# Altium schematic sheet Configuration Switches and DAC Rev 5.SchDoc("Configuration Switches and DAC Rev 5")
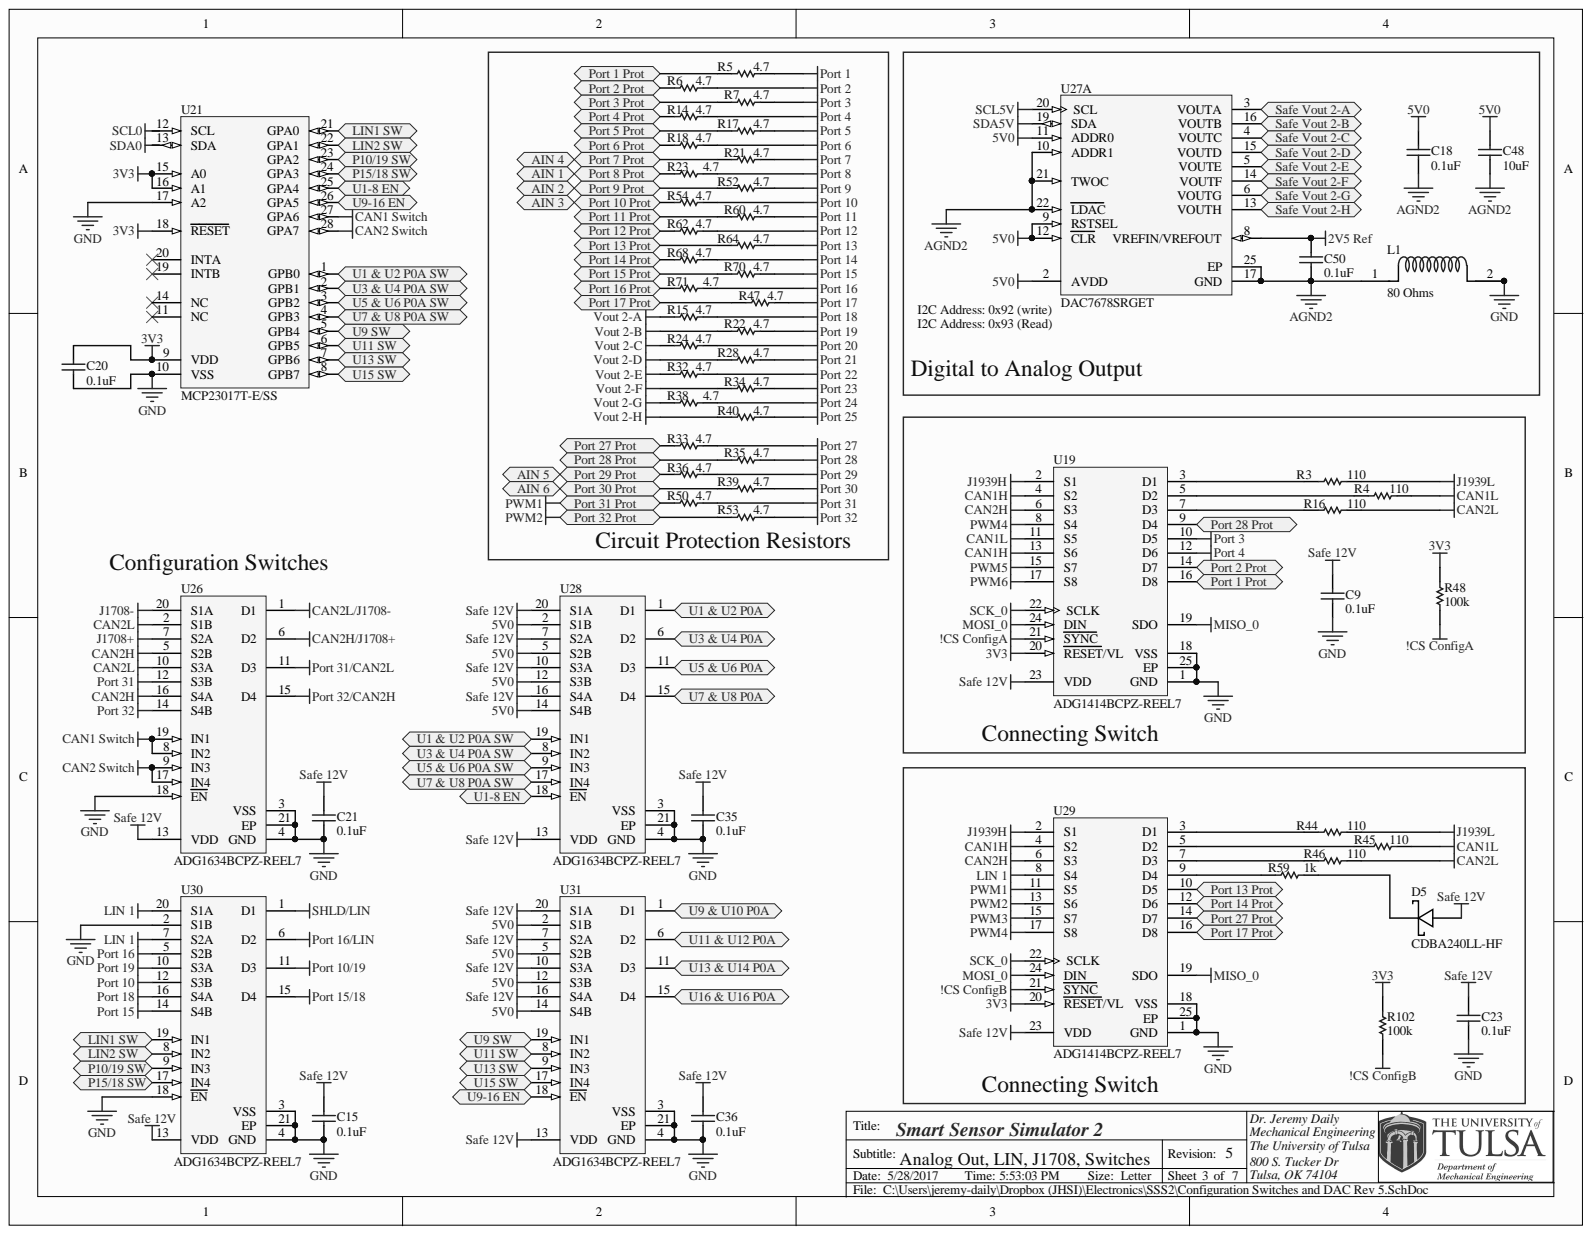
[diagram p0: Configuration Switches and DAC Rev 5.SchDoc]

## Parts
C9  0.1uF  [0603]
C15  0.1uF  [0603]
C18  0.1uF  [0603]
C20  0.1uF  [0603]
C21  0.1uF  [0603]
C23  0.1uF  [0603]
C35  0.1uF  [0603]
C36  0.1uF  [0603]
C48  10uF  [0603]
C50  0.1uF  [0603]
D5  CDBA240LL-HF  [SMA with A and K]
L1  80 Ohms  [0805]
R3  110  [0603]
R4  110  [0603]
R5  4.7  [0603]
R6  4.7  [0603]
R7  4.7  [0603]
R14  4.7  [0603]
R15  4.7  [0603]
R16  110  [0603]
R17  4.7  [0603]
R18  4.7  [0603]
R21  4.7  [0603]
R22  4.7  [0603]
R23  4.7  [0603]
R24  4.7  [0603]
R28  4.7  [0603]
R32  4.7  [0603]
R33  4.7  [0603]
R34  4.7  [0603]
R35  4.7  [0603]
R36  4.7  [0603]
R38  4.7  [0603]
R39  4.7  [0603]
R40  4.7  [0603]
R44  110  [0603]
R45  110  [0603]
R46  110  [0603]
R47  4.7  [0603]
R48  100k  [0603]
R50  4.7  [0603]
R52  4.7  [0603]
R53  4.7  [0603]
R54  4.7  [0603]
R59  1k
R60  4.7  [0603]
R62  4.7  [0603]
R64  4.7  [0603]
R68  4.7  [0603]
R70  4.7  [0603]
R71  4.7  [0603]
R102  100k  [0603]
U19  ADG1414BCPZ-REEL7  [ADI-CP-24-3_M]
U21  MCP23017T-E/SS  [SSOP-SS28_N]
U26  ADG1634BCPZ-REEL7  [ADI-CP-20-4_N]
U27  DAC7678SRGET  [TI-RGE24-2700X2700TP_V]  (part A)
U28  ADG1634BCPZ-REEL7  [ADI-CP-20-4_N]
U29  ADG1414BCPZ-REEL7  [ADI-CP-24-3_M]
U30  ADG1634BCPZ-REEL7  [ADI-CP-20-4_N]
U31  ADG1634BCPZ-REEL7  [ADI-CP-20-4_N]

## Ports
AIN 1, AIN 2, AIN 3, AIN 4, AIN 5, AIN 6, LIN1 SW, LIN2 SW, P10/19 SW, P15/18 SW, PORT 1 PROT, PORT 2 PROT, PORT 3 PROT, PORT 4 PROT, PORT 5 PROT, PORT 6 PROT, PORT 7 PROT, PORT 8 PROT, PORT 9 PROT, PORT 10 PROT, PORT 11 PROT, PORT 12 PROT, PORT 13 PROT, PORT 14 PROT, PORT 15 PROT, PORT 16 PROT, PORT 17 PROT, PORT 27 PROT, PORT 28 PROT, PORT 29 PROT, PORT 30 PROT, PORT 31 PROT, PORT 32 PROT, SAFE VOUT 2-A, SAFE VOUT 2-B, SAFE VOUT 2-C, SAFE VOUT 2-D, SAFE VOUT 2-E, SAFE VOUT 2-F, SAFE VOUT 2-G, SAFE VOUT 2-H, U1 & U2 P0A, U1 & U2 P0A SW, U1-8 EN, U3 & U4 P0A, U3 & U4 P0A SW, U5 & U6 P0A, U5 & U6 P0A SW, U7 & U8 P0A, U7 & U8 P0A SW, U9 & U10 P0A, U9 SW, U9-16 EN, U11 & U12 P0A, U11 SW, U13 & U14 P0A, U13 SW, U15 SW, U16 & U16 P0A

## Nets
2V5 REF: C50.2 U27.8(VREFIN/VREFOUT)
3V3: C20.2 R48.2 R102.2 U19.20(RESET/VL) U21.9(VDD) U21.15(A0) U21.16(A1) U21.18(RESET) U29.20(RESET/VL)
5V0: C18.2 C48.2 U27.2(AVDD) U27.9(RSTSEL) U27.11(ADDR0) U27.12(CLR) U28.2(S1B) U28.5(S2B) U28.12(S3B) U28.14(S4B) U31.2(S1B) U31.5(S2B) U31.12(S3B) U31.14(S4B)
!CS CONFIGA: R48.1 U19.21(SYNC)
!CS CONFIGB: R102.1 U29.21(SYNC)
AGND2: C18.1 C48.1 C50.1 L1.1 U27.10(ADDR1) U27.17(GND) U27.21(TWOC) U27.22(LDAC) U27.25(EP)
CAN1 SWITCH: U21.27(GPA6) U26.8(IN2) U26.19(IN1)
CAN1H: U19.4(S2) U19.13(S6) U29.4(S2)
CAN1L: R4.1 R45.1 U19.11(S5)
CAN2 SWITCH: U21.28(GPA7) U26.9(IN3) U26.17(IN4)
CAN2H: U19.6(S3) U26.5(S2B) U26.16(S4A) U29.6(S3)
CAN2H/J1708+: U26.6(D2)
CAN2L: R16.2 R46.2 U26.2(S1B) U26.10(S3A)
CAN2L/J1708-: U26.1(D1)
GND: C9.1 C15.1 C20.1 C21.1 C23.1 C35.1 C36.1 L1.2 U19.1(GND) U19.18(VSS) U19.25(EP) U21.10(VSS) U21.17(A2) U26.3(VSS) U26.4(GND) U26.18(EN) U26.21(EP) U28.3(VSS) U28.4(GND) U28.21(EP) U29.1(GND) U29.18(VSS) U29.25(EP) U30.2(S1B) U30.3(VSS) U30.4(GND) U30.18(EN) U30.21(EP) U31.3(VSS) U31.4(GND) U31.21(EP)
J1708+: U26.7(S2A)
J1708-: U26.20(S1A)
J1939H: U19.2(S1) U29.2(S1)
J1939L: R3.1 R44.1
LIN 1: U29.8(S4) U30.7(S2A) U30.20(S1A)
MISO_0: U19.19(SDO) U29.19(SDO)
MOSI_0: U19.24(DIN) U29.24(DIN)
PORT 1: R5.1
PORT 2: R6.1
PORT 3: R7.1 U19.10(D5)
PORT 4: R14.1 U19.12(D6)
PORT 5: R17.1
PORT 6: R18.1
PORT 7: R21.1
PORT 8: R23.2
PORT 9: R52.1
PORT 10: R54.1 U30.12(S3B)
PORT 10/19: U30.11(D3)
PORT 11: R60.1
PORT 12: R62.1
PORT 13: R64.1
PORT 14: R68.1
PORT 15: R70.1 U30.14(S4B)
PORT 15/18: U30.15(D4)
PORT 16: R71.2 U30.5(S2B)
PORT 16/LIN: U30.6(D2)
PORT 17: R47.1
PORT 18: R15.1 U30.16(S4A)
PORT 19: R22.1 U30.10(S3A)
PORT 20: R24.1
PORT 21: R28.1
PORT 22: R32.1
PORT 23: R34.1
PORT 24: R38.2
PORT 25: R40.1
PORT 27: R33.1
PORT 28: R35.1
PORT 29: R36.1
PORT 30: R39.1
PORT 31: R50.1 U26.12(S3B)
PORT 31/CAN2L: U26.11(D3)
PORT 32: R53.1 U26.14(S4B)
PORT 32/CAN2H: U26.15(D4)
PWM1: R50.2 U29.11(S5) ; port PORT 31 PROT
PWM2: R53.2 U29.13(S6) ; port PORT 32 PROT
PWM3: U29.15(S7)
PWM4: U19.8(S4) U29.17(S8)
PWM5: U19.15(S7)
PWM6: U19.17(S8)
SAFE 12V: C9.2 C15.2 C21.2 C23.2 C35.2 C36.2 D5.1 U19.23(VDD) U26.13(VDD) U28.7(S2A) U28.10(S3A) U28.13(VDD) U28.16(S4A) U28.20(S1A) U29.23(VDD) U30.13(VDD) U31.7(S2A) U31.10(S3A) U31.13(VDD) U31.16(S4A) U31.20(S1A)
SCK_0: U19.22(SCLK) U29.22(SCLK)
SCL0: U21.12(SCL)
SCL5V: U27.20(SCL)
SDA0: U21.13(SDA)
SDA5V: U27.19(SDA)
SHLD/LIN: U30.1(D1)
VOUT 2-A: R15.2
VOUT 2-B: R22.2
VOUT 2-C: R24.2
VOUT 2-D: R28.2
VOUT 2-E: R32.2
VOUT 2-F: R34.2
VOUT 2-G: R38.1
VOUT 2-H: R40.2
AIN 1: R23.1 ; port AIN 1
AIN 2: R52.2 ; port AIN 2
AIN 3: R54.2 ; port AIN 3
AIN 4: R21.2 ; port AIN 4
AIN 5: R36.2 ; port AIN 5
AIN 6: R39.2 ; port AIN 6
LIN1 SW: U21.21(GPA0) U30.19(IN1) ; port LIN1 SW
LIN2 SW: U21.22(GPA1) U30.8(IN2) ; port LIN2 SW
P10/19 SW: U21.23(GPA2) U30.9(IN3) ; port P10/19 SW
P15/18 SW: U21.24(GPA3) U30.17(IN4) ; port P15/18 SW
U1 & U2 P0A: U28.1(D1) ; port U1 & U2 P0A
U1 & U2 P0A SW: U21.1(GPB0) U28.19(IN1) ; port U1 & U2 P0A SW
U1-8 EN: U21.25(GPA4) U28.18(EN) ; port U1-8 EN
U3 & U4 P0A: U28.6(D2) ; port U3 & U4 P0A
U3 & U4 P0A SW: U21.2(GPB1) U28.8(IN2) ; port U3 & U4 P0A SW
U5 & U6 P0A: U28.11(D3) ; port U5 & U6 P0A
U5 & U6 P0A SW: U21.3(GPB2) U28.9(IN3) ; port U5 & U6 P0A SW
U7 & U8 P0A: U28.15(D4) ; port U7 & U8 P0A
U7 & U8 P0A SW: U21.4(GPB3) U28.17(IN4) ; port U7 & U8 P0A SW
U9 & U10 P0A: U31.1(D1) ; port U9 & U10 P0A
U9 SW: U21.5(GPB4) U31.19(IN1) ; port U9 SW
U9-16 EN: U21.26(GPA5) U31.18(EN) ; port U9-16 EN
U11 & U12 P0A: U31.6(D2) ; port U11 & U12 P0A
U11 SW: U21.6(GPB5) U31.8(IN2) ; port U11 SW
U13 & U14 P0A: U31.11(D3) ; port U13 & U14 P0A
U13 SW: U21.7(GPB6) U31.9(IN3) ; port U13 SW
U15 SW: U21.8(GPB7) U31.17(IN4) ; port U15 SW
U16 & U16 P0A: U31.15(D4) ; port U16 & U16 P0A
NetD5_2: D5.2 R59.1
NetR3_2: R3.2 U19.3(D1)
NetR4_2: R4.2 U19.5(D2)
NetR5_2: R5.2 U19.16(D8) ; port PORT 1 PROT
NetR6_2: R6.2 U19.14(D7) ; port PORT 2 PROT
NetR7_2: R7.2 ; port PORT 3 PROT
NetR14_2: R14.2 ; port PORT 4 PROT
NetR16_1: R16.1 U19.7(D3)
NetR17_2: R17.2 ; port PORT 5 PROT
NetR18_2: R18.2 ; port PORT 6 PROT
NetR33_2: R33.2 U29.14(D7) ; port PORT 27 PROT
NetR35_2: R35.2 U19.9(D4) ; port PORT 28 PROT
NetR44_2: R44.2 U29.3(D1)
NetR45_2: R45.2 U29.5(D2)
NetR46_1: R46.1 U29.7(D3)
NetR47_2: R47.2 U29.16(D8) ; port PORT 17 PROT
NetR59_2: R59.2 U29.9(D4)
NetR60_2: R60.2 ; port PORT 11 PROT
NetR62_2: R62.2 ; port PORT 12 PROT
NetR64_2: R64.2 U29.10(D5) ; port PORT 13 PROT
NetR68_2: R68.2 U29.12(D6) ; port PORT 14 PROT
NetR70_2: R70.2 ; port PORT 15 PROT
NetR71_1: R71.1 ; port PORT 16 PROT
NetU27_3: U27.3(VOUTA) ; port SAFE VOUT 2-A
NetU27_4: U27.4(VOUTC) ; port SAFE VOUT 2-C
NetU27_5: U27.5(VOUTE) ; port SAFE VOUT 2-E
NetU27_6: U27.6(VOUTG) ; port SAFE VOUT 2-G
NetU27_13: U27.13(VOUTH) ; port SAFE VOUT 2-H
NetU27_14: U27.14(VOUTF) ; port SAFE VOUT 2-F
NetU27_15: U27.15(VOUTD) ; port SAFE VOUT 2-D
NetU27_16: U27.16(VOUTB) ; port SAFE VOUT 2-B

Not connected: U21 11(NC) 14(NC) 19(INTB) 20(INTA)

## Library data of the parts
C9 C15 C18 C20 C21 C23 C35 C36 C50 = Cap: CAP CER 0.1UF 50V 10% X7R 0603; Manufacturer: Murata Electronics North America; PartNumber: GRM188R71H104KA93D; Component Kind: Standard; Library Name: SSS Schematic Library.SchLib; Signal Integrity: Cap; Simulation: CAP; Supplier 1: Digi-Key; Supplier Part Number 1: 490-1519-1-ND
C48 = Cap: 10µF ±20% 25V Ceramic Capacitor X5R 0603 (1608 Metric); Manufacturer: Murata Electronics North America; PartNumber: GRT188R61E106ME13D; Component Kind: Standard; Library Name: SSS Integrated Library.IntLib; Signal Integrity: Cap; Simulation: CAP; Supplier 1: Digi-Key; Supplier Part Number 1: 490-12323-1-ND
D5 = Schottky Diode: Diode Schottky 40V 2A Surface Mount DO-214AC (SMA); Manufacturer: Comchip Technology; Component Kind: Standard; Library Name: SSS Integrated Library.IntLib; PartNumber: CDBA240LL-HF; Supplier 1: Digi-Key; Supplier Part Number 1: 641-1698-1-ND
L1 = Ferrite Bead: Ferrite Bead 80ohms 100MHz 5A Monolithic 0805 SMD; Manufacturer: Laird Technologies; PartNumber: HI0805R800R-10; Component Kind: Standard; Library Name: SSS Integrated Library.IntLib; Supplier 1: Mouser; Supplier Part Number 1: 875-HI0805R800R-10
R3 R4 R16 R44-R46 = Chip Resistor: RES SMD 110 OHM 1% 1/10W 0603; Manufacturer: Panasonic Electronic Components; PartNumber: ERJ-3EKF1100V; Component Kind: Standard; Library Name: SSS Schematic Library.SchLib; Supplier 1: Digi-Key; Supplier Part Number 1: P110HCT-ND
R5-R7 R14 R15 R17 R18 R21-R24 R28 R32-R36 R38-R40 R47 R50 R52-R54 R60 R62 R64 R68 R70 R71 = Chip Resistor: 4.7 Ohm ±5% 0.25W, 1/4W Chip Resistor 0603 (1608 Metric) Automotive AEC-Q200, Pulse Withstanding Thick Film; Manufacturer: Panasonic Electronic Components; PartNumber: P4.7BZCT-ND; Component Kind: Standard; Library Name: SSS Integrated Library.IntLib; Supplier 1: Digi-Key; Supplier Part Number 1: ERJ-PA3J4R7V
R48 R102 = Chip Resistor: RES SMD 100K OHM 1% 1/10W 0603; Manufacturer: Panasonic Electronic Components; PartNumber: ERJ-3EKF1003V; Component Kind: Standard; Library Name: SSS Integrated Library.IntLib; Supplier 1: Digi-Key; Supplier Part Number 1: P100KHCT-ND
R59 = Chip Resistor: RES SMD 1K OHM 1% 1/10W 0603; Manufacturer: Panasonic Electronic Components; PartNumber: ERJ-3EKF1001V; Component Kind: Standard; Library Name: SSS Schematic Library.SchLib; Supplier 1: Digi-Key; Supplier Part Number 1: P1.00KHCT-ND
U19 U29 = ADG1414BCPZ-REEL7: 9.5 Ohm RON +/-15 V / +12 V / +/-5 V iCMOS Serially-Controlled Octal SPST Switch, -40 to 125 degC, 24-pin QFN (CP-24-3), Reel; Manufacturer: Analog Devices; Component Kind: Standard; Library Name: Analog Devices Switches and Multiplexers Analog Switches.IntLib; PartNumber: ADG1414BCPZ-REEL7; Supplier 1: Digi-Key; Supplier Part Number 1: ADG1414BCPZ-REEL7TR-ND
U21 = MCP23017T-E/SS: 16-Bit I/O Expander with Serial Interface, 28-Pin SSOP, Extended Temperature, Tape and Reel; Manufacturer: Microchip; Component Kind: Standard; Library Name: Microchip Interface.IntLib; PartNumber: MCP23017T-E/SS; Supplier 1: Digi-Key; Supplier Part Number 1: MCP23017T-E/SSCT-ND
U26 U28 U30 U31 = ADG1634BCPZ-REEL7: IC SWITCH QUAD SPDT 20LFCSP; Manufacturer: Analog Devices; Component Kind: Standard; Library Name: Analog Devices Switches and Multiplexers Analog Switches.IntLib; PartNumber: ADG1634BCPZ-REEL7; Supplier 1: Digi-Key; Supplier Part Number 1: ADG1634BCPZ-REEL7CT-ND
U27 = DAC7678SRGET: 12-Bit, Octal Channel, Ultra-Low Glitch, Voltage Output, 2-Wire Interface DAC with 2.5 V Internal Reference, -40 to 125 degC, 24-pin QFN (RGE24), Green (RoHS & no Sb/Br); Manufacturer: Texas Instruments; Component Kind: Standard; PartNumber: DAC7678SRGET; Supplier 1: Digi-Key; Supplier Part Number 1: 296-27743-1-ND; Library Name: TI Data Converters Digital to Analog Converter.IntLib
